# Altium schematic sheet RF Designing.SchDoc("RF Designing")
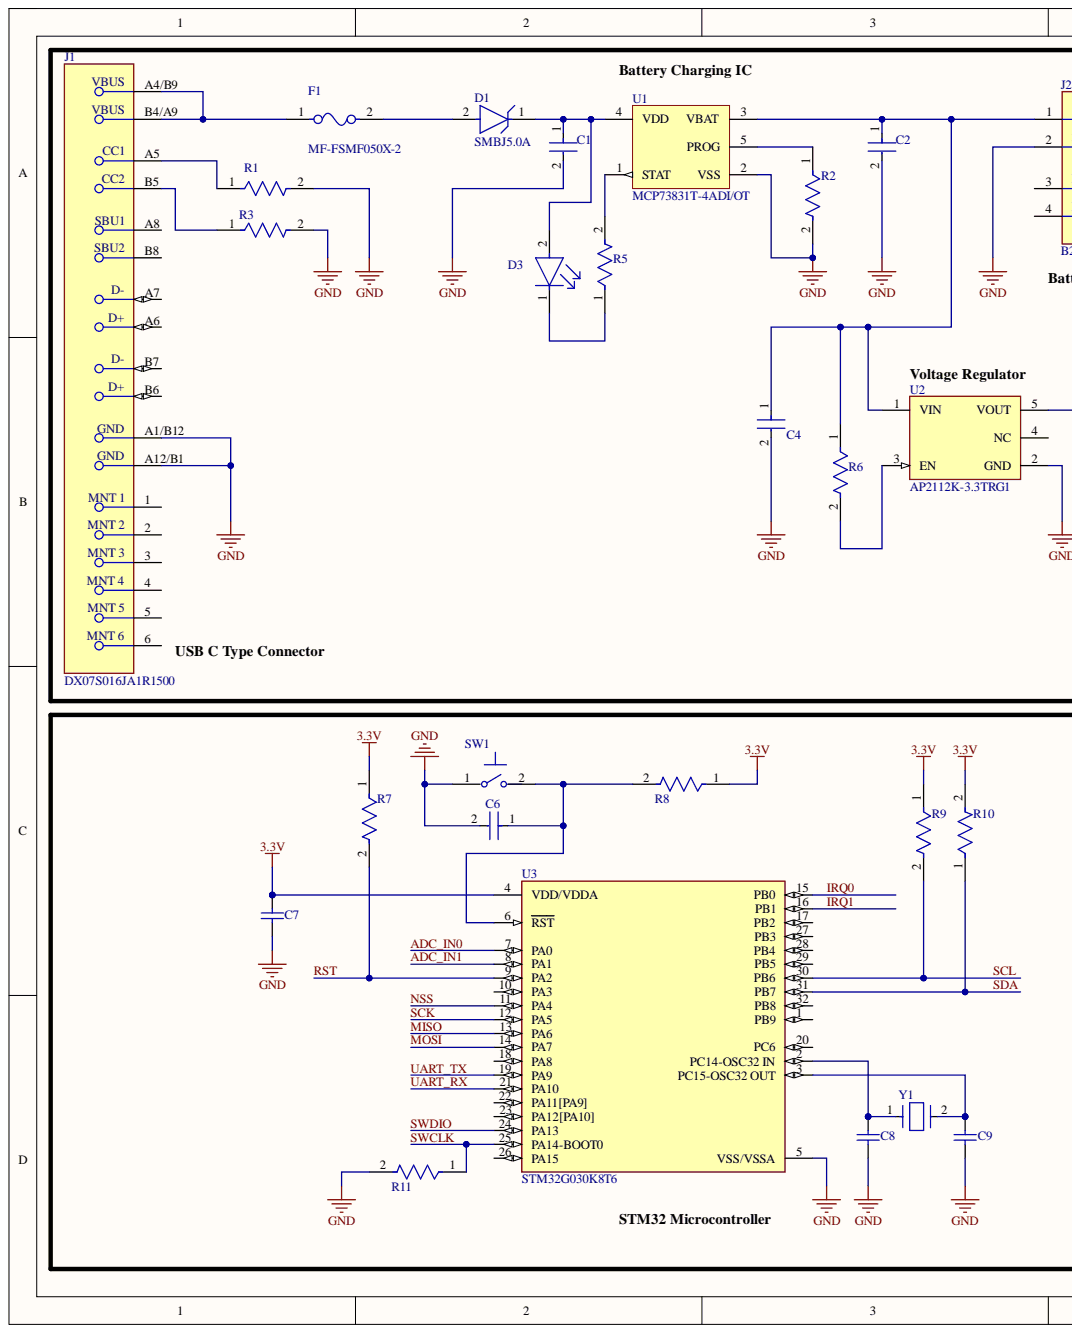
[diagram p0: RF Designing.SchDoc, left]
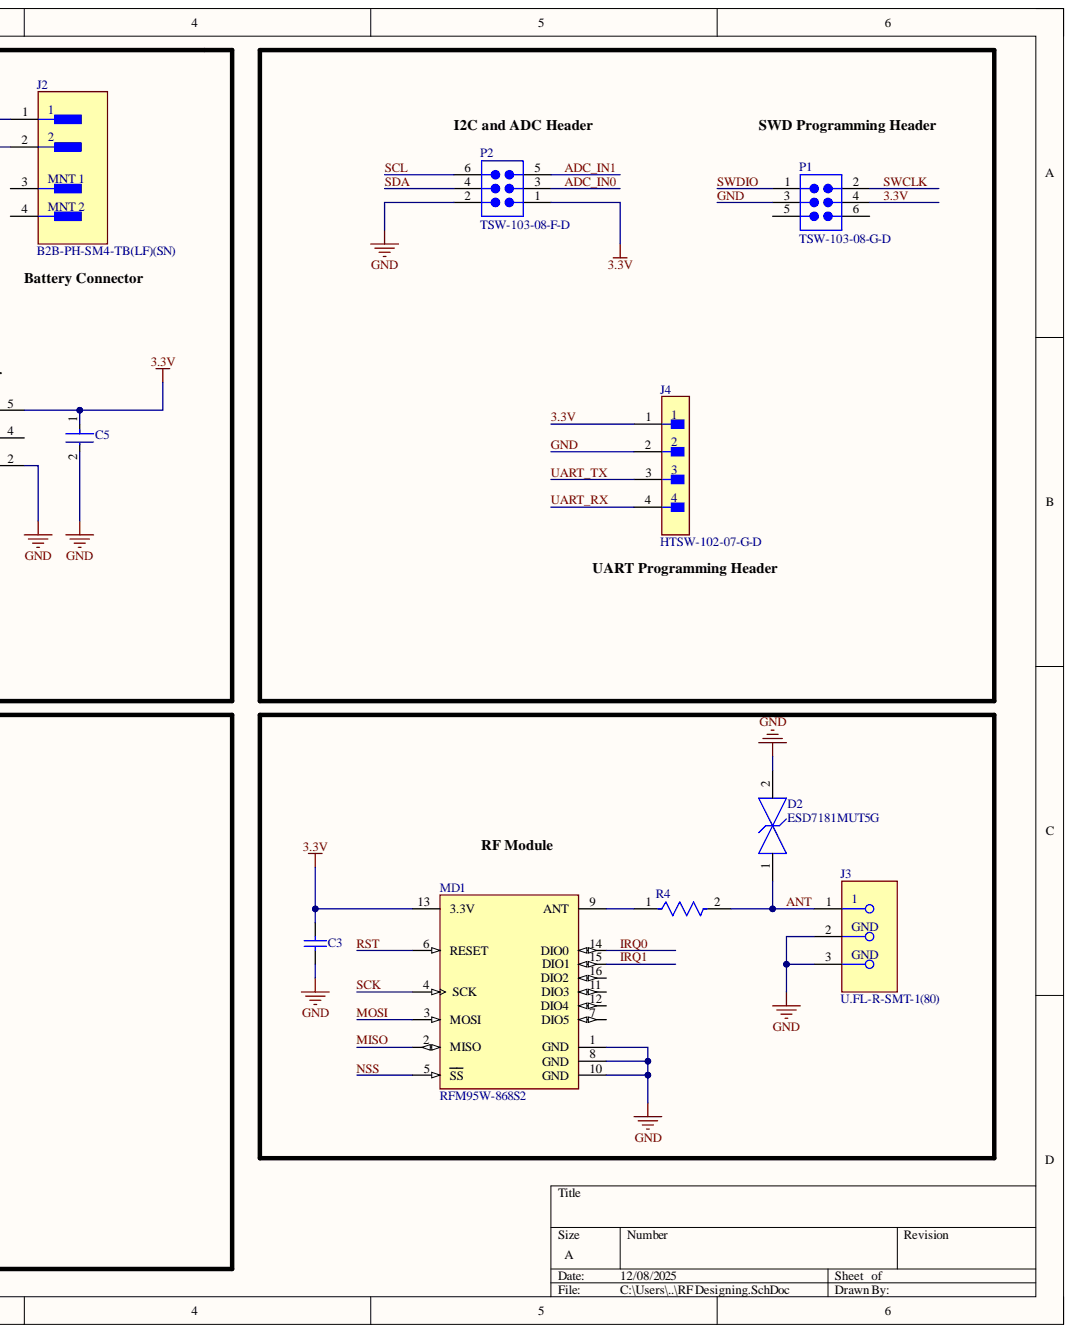
[diagram p1: RF Designing.SchDoc, right]

## Parts
C1  GRM188R61E475KE11J  [FP-GRM188-0_15-IPC_A]  (value not drawn)
C2  GRM188R61E475KE11J  [FP-GRM188-0_15-IPC_A]  (value not drawn)
C3  06031A100CAT2A  [CAPC1608X90X35ML15T15]  (value not drawn)
C4  GRM155C81A105KA12J  [FP-GRM155-0_05-IPC_A]  (value not drawn)
C5  GRM155C81A105KA12J  [FP-GRM155-0_05-IPC_A]  (value not drawn)
C6  GRM033R61A104KE15D  [FP-GRM033-0_03-IPC_C]  (value not drawn)
C7  06031A100CAT2A  [CAPC1608X90X35ML15T15]  (value not drawn)
C8  VJ0603Y104KXJAC  [CAPC1608X92X38NL15T08]  (value not drawn)
C9  VJ0603Y104KXJAC  [CAPC1608X92X38NL15T08]  (value not drawn)
D1  SMBJ5.0A  [FP-SMBJ-MFG]
D2  ESD7181MUT5G  [FP-152AF-MFG]
D3  LS R976-NR-1  [FP-LS_R976-MFG]  (value not drawn)
F1  MF-FSMF050X-2  [FP-MF-FSMF-MFG]
J1  DX07S016JA1R1500  [FP-DX07S016JA1R1500-MFG]
J2  B2B-PH-SM4-TB(LF)(SN)  [FP-B2B-PH-SM4-TB_LF_SN-MFG]
J3  U.FL-R-SMT-1(80)  [FP-U_FL-R-SMT-1_80-MFG]
J4  HTSW-102-07-G-D  [FP-HTSW-102-07-G-D-MFG]
MD1  RFM95W-868S2  [FP-RFM95W-868S2-MFG]
P1  TSW-103-08-G-D  [SMTC-TSW-103-08-X-D]
P2  TSW-103-08-F-D  [SMTC-TSW-103-08-X-D]
R1  AC0603FR-075K1L  [FP-AC0603-MFG]  (value not drawn)
R2  RP73PF1J2K0BTDF  [FP-RP73P-1J-MFG]  (value not drawn)
R3  AC0603FR-075K1L  [FP-AC0603-MFG]  (value not drawn)
R4  CRG0603ZR  [FP-CRG0603-IPC_C]  (value not drawn)
R5  RT0603BRD07470RL  [FP-RT0603-MFG]  (value not drawn)
R6  ERJ-U02F1003X  [FP-ERJU02-MFG]  (value not drawn)
R7  RT0603FRE1010KL  [FP-RT0603-MFG]  (value not drawn)
R8  RT0603FRE1010KL  [FP-RT0603-MFG]  (value not drawn)
R9  CRG0402F4K7  [FP-CRG0402-IPC_B]  (value not drawn)
R10  CRG0402F4K7  [FP-CRG0402-IPC_B]  (value not drawn)
R11  RT0603FRE1010KL  [FP-RT0603-MFG]  (value not drawn)
SW1  TL1015AF160QG  [FP-TL1015AF160QG-MFG]  (value not drawn)
U1  MCP73831T-4ADI/OT  [SOT-23-OT5_N]
U2  AP2112K-3.3TRG1  [FP-SOT25-IPC_A]
U3  STM32G030K8T6  [FP-LQFP32-5V-IPC_A]
Y1  ABS07-32.768KHZ-7-T  [FP-ABS07-MFG]  (value not drawn)

## Nets
3.3V: C3.2 C5.1 C7.2 J4.1 MD1.13(3.3V) P1.4 P2.1 R7.1 R8.1 R9.1 R10.2 U2.5(VOUT) U3.4(VDD/VDDA)
ADC_IN0: P2.3 U3.7(PA0)
ADC_IN1: P2.5 U3.8(PA1)
ANT: D2.1 J3.1 R4.2
GND: C1.2 C2.2 C3.1 C4.2 C5.2 C6.2 C7.1 C8.1 C9.1 D2.2 J1.A1/B12 J1.A12/B1 J2.2 J3.2 J3.3 J4.2 MD1.1(GND) MD1.8(GND) MD1.10(GND) P1.3 P2.2 R1.2 R2.2 R3.2 R11.2 SW1.1 U1.2(VSS) U2.2(GND) U3.5(VSS/VSSA)
IRQ0: MD1.14(DIO0) U3.15(PB0)
IRQ1: MD1.15(DIO1) U3.16(PB1)
MISO: MD1.2(MISO) U3.13(PA6)
MOSI: MD1.3(MOSI) U3.14(PA7)
NSS: MD1.5(SS) U3.11(PA4)
RST: MD1.6(RESET) R7.2 U3.9(PA2)
SCK: MD1.4(SCK) U3.12(PA5)
SCL: P2.6 R9.2 U3.30(PB6)
SDA: P2.4 R10.1 U3.31(PB7)
SWCLK: P1.2 R11.1 U3.25(PA14-BOOT0)
SWDIO: P1.1 U3.24(PA13)
UART_RX: J4.4 U3.21(PA10)
UART_TX: J4.3 U3.19(PA9)
NetC1_1: C1.1 D1.1 D3.2 U1.4(VDD)
NetC2_1: C2.1 C4.1 J2.1 R6.1 U1.3(VBAT) U2.1(VIN)
NetC6_1: C6.1 R8.2 SW1.2 U3.6(RST)
NetC8_2: C8.2 U3.2(PC14-OSC32_IN) Y1.1
NetC9_2: C9.2 U3.3(PC15-OSC32_OUT) Y1.2
NetD1_2: D1.2 F1.2
NetD3_1: D3.1 R5.1
NetF1_1: F1.1 J1.A4/B9 J1.B4/A9
NetJ1_A5: J1.A5 R1.1
NetJ1_B5: J1.B5 R3.1
NetMD1_9: MD1.9(ANT) R4.1
NetR2_1: R2.1 U1.5(PROG)
NetR5_2: R5.2 U1.1(STAT)
NetR6_2: R6.2 U2.3(EN)

Not connected: J1 1 2 3 4 5 6 A6 A7 A8 B6 B7 B8; J2 3 4; MD1 7(DIO5) 11(DIO3) 12(DIO4) 16(DIO2); P1 5 6; U2 4(NC); U3 1(PB9) 10(PA3) 17(PB2) 18(PA8) 20(PC6) 22(PA11[PA9]) 23(PA12[PA10]) 26(PA15) 27(PB3) 28(PB4) 29(PB5) 32(PB8)

## Library data of the parts
C1 C2 = CMP-06035-051692-1: Chip Multilayer Ceramic Capacitors for General Purpose, 0603, 4.7uF, X5R, 15%, 10%, 25V; Capacitance: 4.7uF; Case/Package: 0603; Component Kind: Standard; Dielectric: X5R; Length: 0.063inch; Library Name: Altium Content Vault; Max Operating Temperature: 85°C; Min Operating Temperature: -55°C; Mount: Surface Mount; Package Quantity: 10000; Packaging: Tape and Reel; Thickness: 0.037inch; Tolerance: 10%; Voltage Rating: 25V; Voltage Rating (DC): 25V; Weight: 0.000222oz; Width: 0.031inch
C3 C7 = CMP-2006-03979-1: Manufacturer: AVX Interconnect / Elco; Component Kind: Standard; Library Name: Altium Content Vault; Part Number: 06031A100CAT2A
C4 C5 = CMP-06035-052171-1: Chip Multilayer Ceramic Capacitors for General Purpose, 0402, 1.0uF, X6S, 22%, 10%, 10V; Capacitance: 1uF; Case/Package: 0402; Component Kind: Standard; Dielectric: X6S; Length: 0.039inch; Library Name: Altium Content Vault; Max Operating Temperature: 105°C; Min Operating Temperature: -55°C; Mount: Surface Mount; Package Quantity: 50000; Packaging: Tape and Reel; Thickness: 0.022inch; Tolerance: 10%; Voltage Rating: 10V; Voltage Rating (DC): 10V; Weight: 0.000212oz; Width: 0.02inch
C6 = CMP-2010-01264-2: Chip Multilayer Ceramic Capacitors for General Purpose, 0201, 0.10uF, X5R, 15%, 10%, 10V; Capacitance: 100nF; Case Code (Imperial): 0201; Case Code (Metric): 0603; Case/Package: 0201; Component Kind: Standard; Depth: 0.3mm; Dielectric: X5R; Height: 0.3mm; Lead Free: Lead Free; Length: 0.6mm; Library Name: Altium Content Vault; Max Operating Temperature: 85°C; Min Operating Temperature: -55°C; Mount: Surface Mount; Package Quantity: 1; Packaging: Tape and Reel; Radiation Hardening: No; REACH SVHC: No SVHC; Termination: SMD/SMT; Thickness: 0.013inch; Tolerance: 10%; Voltage: 10V; Voltage Rating: 10V; Voltage Rating (DC): 10V; Weight: 6E-06oz; Width: 0.012inch
C8 C9 = CMP-2006-01976-1: Manufacturer: Vitramon; Component Kind: Standard; Library Name: Altium Content Vault; Part Number: VJ0603Y104KXJAC
D1 = CMP-07401-000003-1: TVS DIODE 5V 9.2V SMB; Manufacturer: Bourns Inc.; Breakdown Voltage: 6.4V; Direction: Unidirectional; Max Junction Temp: +150°C; Number Of Channels: 1; Peak Pulse Current: 65.3A; Peak Pulse Power: 600W; Standoff Height: 0.05mm; Automotive: No; Category: Diode; Clamping Voltage: 9.2V; Component Kind: Standard; ComponentLink2Description: Datasheet; ComponentLink2URL: https://www.bourns.com/docs/Product-Datasheets/SMBJ.pdf; Device Class L1: Circuit Protection; Device Class L2: TVS Diodes; Device Class L3: unset; Digikey Description: TVS DIODE 5V 9.2V SMB; Height: 2.44mm; Lead Free: Yes; Library Name: Altium Content Vault; Manufacturer Part Number: SMBJ5.0A; Mouser Description: Dio Tvs Vwm 5.0V 600W Unidir Smb Rohs Compliant: Yes; Octopart: https://octopart.com/smbj5.0a-bourns-12809487; Package: SMBJ; Reverse Standoff Voltage: 5V; RoHS: TRUE; Temperature: -55°C to +150°C; Temperature Range High: +150°C; Temperature Range Low: -55°C
D2 = CMP-00278-33922270-1: ESD7181MUT5G ESD Suppressor Diode TVS Bi-Dir 18.5V 2-Pin X3-DFN T/R / TVS DIODE 18.5VWM 35VC; Manufacturer Lifecycle Status: ACTIVE; Capacitance: 600fF; Clamping Voltage: 35V; Direction: Bidirectional; Element Configuration: Single; Min Breakdown Voltage: 20.5V; Number of Bidirectional Channels: 1; Peak Pulse Current: 1A; Power Line Protection: No; Case/Package: DFN; Component Kind: Standard; Composition: Zener; Contact Plating: Gold; Lead Free: Lead Free; Library Name: Altium Content Vault; Lifecycle Status: Production; Max Operating Temperature: 150°C; Min Operating Temperature: -55°C; Mount: Surface Mount; NexarPartId: 33922270; Number of Pins: 2; Operating Supply Voltage: 18.5V; Packaging: Tape & Reel (TR); Reverse Standoff Voltage: 18.5V; RoHS: Compliant; Schedule B: 8536300000; Working Voltage: 18.5V
D3 = CMP-62016-000037-1: LED RED DIFFUSED 0805 SMD; Dominant Wavelength: 633nm; Forward Current: 25mA; Forward Voltage: 2.5V; Lens Color: White; Lens Size: 1.25mm; Lens Style: Flat; Luminous Flux: 0.47lm; Luminous Intensity: 180mcd; Number of Elements: 1; Power Dissipation: 65W; Reverse Voltage: 12V; Test Current: 20mA; Viewing Angle: 160°; Case Code (Imperial): 0805; Case Code (Metric): 2012; Case/Package: 0805; Color: Red; Component Kind: Standard; Depth: 1.35mm; Height: 0.9mm; Illumination Color: Red; Length: 2mm; Lens Transparency: Diffused; Library Name: Altium Content Vault; Max Operating Temperature: 85°C; Min Operating Temperature: -30°C; Mount: Surface Mount; Number of LEDs: 1; Number of Pins: 2; Package Quantity: 16000; Packaging: Tape and Reel; Radiation Hardening: No; Width: 1.25mm
F1 = CMP-07400-000010-1: PTC RESET FUSE 6V 500MA 0603; Manufacturer: Bourns Inc.; Current Rating: 40A; Hold Current: 0.5A; Resistance: 0.1-0.8Ω; Trip Current: 1A; Trip Time: 0.1s; Value: 0.1-0.8Ω; Ambient Temperature Range High: +85°C; Ambient Temperature Range Low: -40°C; Automotive: No; Category: Fuse; Component Kind: Standard; ComponentLink2Description: Datasheet; ComponentLink2URL: https://www.bourns.com/docs/Product-Datasheets/mffsmf.pdf; Device Class L1: Circuit Protection; Device Class L2: PTC Resettable Fuses; Device Class L3: unset; Digikey Description: PTC RESET FUSE 6V 500MA 0603; Height: 1mm; Lead Free: Yes; Library Name: Altium Content Vault; Manufacturer Part Number: MF-FSMF050X-2; Mouser Description: Resettable Fuses - PPTC 6volts 40amps; Octopart: https://octopart.com/mf-fsmf050x-2-bourns-10470804; Package: MF-FSMF; RoHS: TRUE; Temperature: -40°C to +85°C; Temperature Range High: +85°C; Temperature Range Low: -40°C; Voltage Rating: 6V
J1 = CMP-19038-000003-1: CONN RCP USB2.0 TYP C 24P SMD RA; Component Kind: Standard; Library Name: Altium Content Vault
J2 = CMP-2000-05163-2: CONN HEADER SMD 2POS 2MM; Contact Resistance: 20mR; Current Rating: 2A; Gender: Male; Insulation Resistance: 1GR; Mated Stacking Heights: 8.6mm; Max Voltage Rating (AC): 100V; Number of Contacts: 2; Color: White; Component Kind: Standard; Connector Type: Header; Contact Finish - Mating: TIN; Contact Material: Copper Alloy; Contact Mating Length: 0.13inch; Contact Plating: Tin; Contact Shape: Square; Contact Type: Male Pin; Depth: 5mm; Fastening Type: Detent; Flammability Rating: UL94 V-0; Height: 9.4mm; Housing Color: Beige; Housing Material: Polyamide; Insulation Color: Natural; Insulation Material: Nylon; Lead Free: Lead Free; Lead Pitch: 2mm; Length: 7.95mm; Library Name: Altium Content Vault; Max Current Rating: 2A; Max Operating Temperature: 85°C; Min Operating Temperature: -25°C; Mount: Surface Mount; Number of Poles: 2; Number of Positions: 2; Number of Rows: 1; Orientation: Straight; Packaging: Tape and Reel; Pitch: 2mm; REACH SVHC: No SVHC; Series: PH; Termination: Solder; Voltage Rating: 100V; Voltage Rating (AC): 100V; Width: 5.5mm; Working Voltage: 100V
J3 = CMP-11598-000004-1: CONN U.FL RCPT STR 50 OHM SMD; Body Material: Bronze; Body Plating: Silver; Center Contact Plating: Gold; Connector Type: Receptacle; Dielectric Material: Polymer; Impedance: 50R; Mating Cycles: 30; Max Frequency: 6GHz; Number of Ports: 1; Case/Package: SMD/SMT; Component Kind: Standard; Contact Material: Brass; Fastening Type: SNAP-ON; Housing Color: Beige; Lead Free: Lead Free; Library Name: Altium Content Vault; Max Operating Temperature: 90°C; Min Operating Temperature: -40°C; Mount: Surface Mount; Package Quantity: 10000; Packaging: Tape and Reel; RoHS Compliant: Yes; Series: U.FL; Termination: Solder
J4 = CMP-02766-000010-1: CONN HEADER VERT 4POS 2.54MM; Manufacturer: Samtec; Conn Gender: Male; Conn Orientation: Straight; Current Rating: 6.3A; Number Of Contacts: 4; Voltage Rating: 550V; Automotive: No; Category: Conn; Component Kind: Standard; ComponentLink2Description: Datasheet; ComponentLink2URL: http://suddendocs.samtec.com/catalog_english/htsw_th.pdf; Contact Material: Gold,Nickel; Device Class L1: Connectors; Device Class L2: Headers and Wire Housings; Device Class L3: unset; Digikey Description: CONN HEADER VERT 4POS 2.54MM; Height: 8.71mm; Lead Free: Yes; Library Name: Altium Content Vault; Manufacturer Part Number: HTSW-102-07-G-D; Mouser Description: Conn Unshrouded Header HDR 4 POS 2.54mm Solder ST Thru-Hole Bag; Number Of Rows: 2; Octopart: https://octopart.com/htsw-102-07-g-d-samtec-10531767; Package: HTSW-102-07-G-D; Pitch: 2.54mm; RoHS: TRUE; Temperature: -55°C to +125°C; Temperature Range High: +125°C; Temperature Range Low: -55°C
MD1 = CMP-204316-000001-1: RX TXRX MODULE ISM < 1GHZ SMD; Current - Receiving: 12.1mA; Current - Transmitting: 120mA; Data Rate: 300Kbps; Frequency: 868MHz; Interface: SPI; Sensitivity: -123dBm; Case/Package: SMD/SMT; Component Kind: Standard; Library Name: Altium Content Vault; Max Operating Temperature: 70°C; Min Operating Temperature: -20°C; Mount: Surface Mount; Packaging: Bulk
P1 = CMP-1024-00338-1: 0.025" SQ Post Header, Through-hole, Vertical, -55 to 125 degC, 2.54 mm Pitch, 6-Pin, Male, RoHS; Manufacturer: Samtec; Pitch: 2.54 mm; Component Kind: Standard; ComponentLink1Description: Manufacturer URL; ComponentLink1URL: http://www.samtec.com; ComponentLink2Description: Datasheet; ComponentLink2URL: http://www.samtec.com/documents/webfiles/pdf/TSW_TH.PDF; ComponentLink3Description: Package Specification; ComponentLink3URL: http://www.samtec.com/documents/webfiles/cpdf/TSW-XXX-XX-XXX-X-XX-XXX-MKT.pdf; DatasheetVersion: F-214; Library Name: Altium Content Vault; Mounting Technology: Through-hole; Orientation: Vertical; PackageDescription: .025[0.64] SQ. Post Terminal Strip Assembly; PackageReference: TSW-103-08-X-D; PackageVersion: DM, 8/1988; PartNumber: TSW-103-08-G-D; RoHS: True; Temperature Range: -55 to 125 degC
P2 = CMP-1024-00337-1: 0.025" SQ Post Header, Through-hole, Vertical, -55 to 125 degC, 2.54 mm Pitch, 6-Pin, Male, RoHS; Manufacturer: Samtec; Pitch: 2.54 mm; Component Kind: Standard; ComponentLink1Description: Manufacturer URL; ComponentLink1URL: http://www.samtec.com; ComponentLink2Description: Datasheet; ComponentLink2URL: http://www.samtec.com/documents/webfiles/pdf/TSW_TH.PDF; ComponentLink3Description: Package Specification; ComponentLink3URL: http://www.samtec.com/documents/webfiles/cpdf/TSW-XXX-XX-XXX-X-XX-XXX-MKT.pdf; DatasheetVersion: F-214; Library Name: Altium Content Vault; Mounting Technology: Through-hole; Orientation: Vertical; PackageDescription: .025[0.64] SQ. Post Terminal Strip Assembly; PackageReference: TSW-103-08-X-D; PackageVersion: DM, 8/1988; PartNumber: TSW-103-08-F-D; RoHS: True; Temperature Range: -55 to 125 degC
R1 R3 = CMP-03412-025080-2: RES 5.1KΩ 1.00% 0.1W 0603; Max Power Dissipation: 100mW; Resistance: 5.1kR; Temperature Coefficient: 100ppm/°C; Tolerance: 1%; Voltage Rating: 50V; Case Code (Imperial): 0603; Case Code (Metric): 1608; Case/Package: 0603; Component Kind: Standard; Features: Moisture Resistant; Height: 0.45mm; Length: 1.6mm; Library Name: Altium Content Vault; Max Operating Temperature: 155°C; Min Operating Temperature: -55°C; Number of Terminations: 2; Packaging: Tape and Reel; Power Rating: 100mW; Ratings: AEC-Q200; Resistor Type: Thick Film; RoHS Compliant: Yes; Series: AC; Termination: SMD/SMT; Weight: 7.1E-05oz; Width: 0.8mm
R2 = CMP-03211-004349-1: RES SMD 2K OHM 0.1% 1/6W 0603; Manufacturer: TE Connectivity; Power Rating: 0.166W; Resistance: 2KΩ; Temperature Coefficient: 25ppm/°C; Tolerance: 0.001; Value: 2KΩ; Voltage Rating: 100V; Automotive: No; Category: Res; Component Kind: Standard; ComponentLink2Description: Datasheet; ComponentLink2URL: https://www.te.com/commerce/DocumentDelivery/DDEController?Action=srchrtrv&DocNm=1773272&DocType=DS&DocLang=English; Composition: Thin Film; Device Class L1: Passive Components; Device Class L2: Resistors; Device Class L3: Chip SMD Resistors; Digikey Description: RES SMD 2K OHM 0.1% 1/6W 0603; Height: 0.55mm; Ipc Land Pattern Name: RESC15580X45; Lead Free: Yes; Library Name: Altium Content Vault; Manufacturer Part Number: RP73PF1J2K0BTDF; Octopart: https://octopart.com/rp73pf1j2k0btdf-te+connectivity-23826916; Package: RP73P-1J; RoHS: TRUE; Series: RP73P; Temperature: -55°C to +155°C; Temperature Range High: +155°C; Temperature Range Low: -55°C
R4 = CMP-03211-011415-1: RES SMD 0 OHM JUMPER 1/10W 0603; Max Power Dissipation: 100mW; Number of Resistors: 1; Resistance: 0mR; Temperature Coefficient: 100ppm/°C; Tolerance: 0%; Voltage Rating: 50V; Case Code (Imperial): 0603; Case Code (Metric): 1608; Case/Package: 0603; Component Kind: Standard; Depth: 0.8mm; ELV: Compliant; Height: 0.45mm; Length: 1.6mm; Library Name: Altium Content Vault; Max Operating Temperature: 125°C; Min Operating Temperature: -55°C; Mount: Surface Mount; Number of Terminations: 2; Package Quantity: 5000; Packaging: Tape and Reel; Power Rating: 100mW; Radiation Hardening: No; Resistor Type: Thick Film; RoHS Compliant: Yes; Series: CRG, Neohm; Technology: THICK FILM; Termination: Solder; Weight: 7.1E-05oz; Width: 0.8mm
R5 = CMP-2000-04460-2: RES SMD 470 OHM 0.1% 1/10W 0603; Manufacturer: Yageo; Power Rating: 0.1W; Resistance: 470Ω; Temperature Coefficient: 25 ppm/°C; Tolerance: 0.001; Value: 470Ω; Voltage Rating: 75V; Automotive: No; Category: Res; Component Kind: Standard; ComponentLink2Description: Datasheet; ComponentLink2URL: http://www.yageo.com/documents/recent/PYu-RT_1-to-0.01_RoHS_L_9.pdf; Composition: Thin Film; Device Class L1: Passive Components; Device Class L2: Resistors; Device Class L3: Chip SMD Resistors; Digikey Description: RES SMD 470 OHM 0.1% 1/10W 0603; Footprint Url: http://www.yageo.com/exep/pages/download/literatures/PYu-R_Mount_10.pdf; Height: 0.55mm; Ipc Land Pattern Name: RESC16080X45; Lead Free: Yes; Library Name: Altium Content Vault; Manufacturer Part Number: RT0603BRD07470RL; Mouser Description: Res Thin Film 0603 470 Ohm 0.1% 1/10W ±25ppm/°C Molded SMD SMD Paper T/R; Octopart: https://octopart.com/rt0603brd07470rl-yageo-1424253; Package: RT0603; RoHS: TRUE; Series: RT; Temperature: -55°C to +155°C; Temperature Range High: +155°C; Temperature Range Low: -55°C
R6 = CMP-2002-06461-2: NexarPartId: 25970999; Current Rating: 1A; Power Rating: 100mW; Resistance: 100kΩ; Temperature Coefficient: 200ppm/°C; Tolerance: 1%; Voltage Rating: 50V; Case Code (Imperial): 0402; Case Code (Metric): 1005; Case/Package: 0402; Component Kind: Standard; Composition: Thick Film; Height: 350µm; Length: 1mm; Library Name: Altium Content Vault; Lifecycle Status: Production; Max Operating Temperature: 155°C; Min Operating Temperature: -55°C; Mount: Surface Mount; Packaging: Cut Tape; Radiation Hardening: No; REACH SVHC: Unknown; RoHS: Compliant; Schedule B: 8533210045, 8533210045; Termination: SMD/SMT; Voltage Rating (DC): 50V; Weight: 793.786648µg; Width: 500µm
R7 R8 R11 = CMP-03412-024264-1: RT0603 10KΩ 0.1W 50 ppm/°C 1.00%; Manufacturer: Yageo; Tolerance: 0.01; Voltage Rating: 75V; Automotive: No; Category: Res; Component Kind: Standard; ComponentLink2Description: Datasheet; ComponentLink2URL: http://www.yageo.com/documents/recent/PYu-RT_1-to-0.01_RoHS_L_9.pdf; Composition: Thin Film; Device Class L1: Passive Components; Device Class L2: Resistors; Device Class L3: Chip SMD Resistors; Footprint Url: http://www.yageo.com/exep/pages/download/literatures/PYu-R_Mount_10.pdf; Height: 0.55mm; Ipc Land Pattern Name: RESC16080X45; Lead Free: Yes; Library Name: Altium Content Vault; Manufacturer Part Number: RT0603FRE1010KL; Octopart: https://octopart.com/rt0603fre1010kl-yageo-26185585; Package: RT0603; Power Rating: 0.1W; Resistance: 10KΩ; RoHS: TRUE; Series: RT; Temperature: -55°C to +155°C; Temperature Coefficient: 50 ppm/°C; Temperature Range High: +155°C; Temperature Range Low: -55°C; Value: 10KΩ
R9 R10 = CMP-03211-011412-1: RES SMD 4.7K OHM 1% 1/16W 0402; Component Kind: Standard; Library Name: Altium Content Vault; RoHS Compliant: Yes
SW1 = CMP-17879-000003-1: SWITCH TACTILE SPST-NO 0.05A 12V; Actuator Length: 0.5mm; Actuator Material: Nylon; Actuator Type: Standard; Contact Current Rating: 50mA; Contact Resistance: 100mR; Electrical Life: 50000Cycles; Illumination Type: Non-Illuminated; Mechanical Life: 200000Cycles; Operating Force: 160gf; Switch Function: Off-Mom; Switch Travel: 0.2mm; Throw Configuration: SPST; Case/Package: SMD/SMT; Color: Beige; Component Kind: Standard; Contact Material: Silver; Contact Plating: Silver; Current Rating: 50mA; Lead Free: Lead Free; Library Name: Altium Content Vault; Max Operating Temperature: 70°C; Max Voltage Rating (DC): 12V; Min Operating Temperature: -20°C; Mount: Surface Mount; Orientation: Straight; Package Quantity: 1; Packaging: Tape and Reel; REACH SVHC: No SVHC; Termination: Gull Wing; Voltage Rating (DC): 12V
U1 = CMP-0133-00137-1: Miniature Single-Cell, Fully Integrated Li-Ion, Li-Polymer Charge Management Controller, 4.4V, 5-Pin SOT-23, Industrial Temperature, Tape and Reel; Code_IPC: SOT23-5; Component Kind: Standard; DatasheetVersion: revE, Sep-2008; Library Name: Altium Content Vault; PackageDescription: 5-Lead Plastic Small Outline Transistor (OT) [SOT-23]; PackageReference: SOT-23-OT5; PackageVersion: revBB, Aug-2009
U2 = CMP-12756-000035-1: IC REG LINEAR 3.3V 600MA SOT25; Accuracy: 1.5%; Dropout Voltage: 250mV; Max Input Voltage: 6V; Min Input Voltage: 2.5V; Number of Outputs: 1; Output Type: Fixed; Polarity: Positive; Quiescent Current: 55uA; Case/Package: SOT-23-5; Component Kind: Standard; Height: 1.3mm; Lead Free: Lead Free; Length: 3.1mm; Library Name: Altium Content Vault; Max Operating Temperature: 85°C; Max Output Current: 600mA; Min Operating Temperature: -40°C; Mount: Surface Mount; Number of Pins: 5; Number of Regulators: 1; Output Current: 600mA; Output Voltage: 3.3V; Package Quantity: 1; Packaging: Tape and Reel; Width: 1.7mm
U3 = CMP-12105-000136-1: IC MCU 32BIT 64KB FLASH 32LQFP; Component Kind: Standard; Library Name: Altium Content Vault; RoHS Compliant: Yes
Y1 = CMP-27762-014373-1: Crystal 32.768kHz ±20ppm (Tol) 7pF FUND 70kΩ 2-Pin CSMD T/R; ESR (Equivalent Series Resistance): 70kR; Insulation Resistance: 500MR; Case/Package: CSMD; Component Kind: Standard; Depth: 1.5mm; Frequency: 32.768kHz; Frequency Stability: 20ppm; Frequency Tolerance: 20ppm; Height: 0.9mm; Lead Free: Lead Free; Length: 3.2mm; Library Name: Altium Content Vault; Load Capacitance: 7pF; Max Operating Temperature: 85°C; Min Operating Temperature: -40°C; Mount: Surface Mount; Number of Pins: 2; Operating Frequency: 32.768 kHz; Package Quantity: 3000; Packaging: Tape and Reel; Radiation Hardening: No; Series: ABS07; Series Resistance: 70kR; Termination: SMD/SMT; Tolerance: 20ppm; Width: 1.5mm
